# Altium schematic sheet Arduino FPGA Top-Level Interface.SchDoc("Arduino FPGA Top-Level Interface")
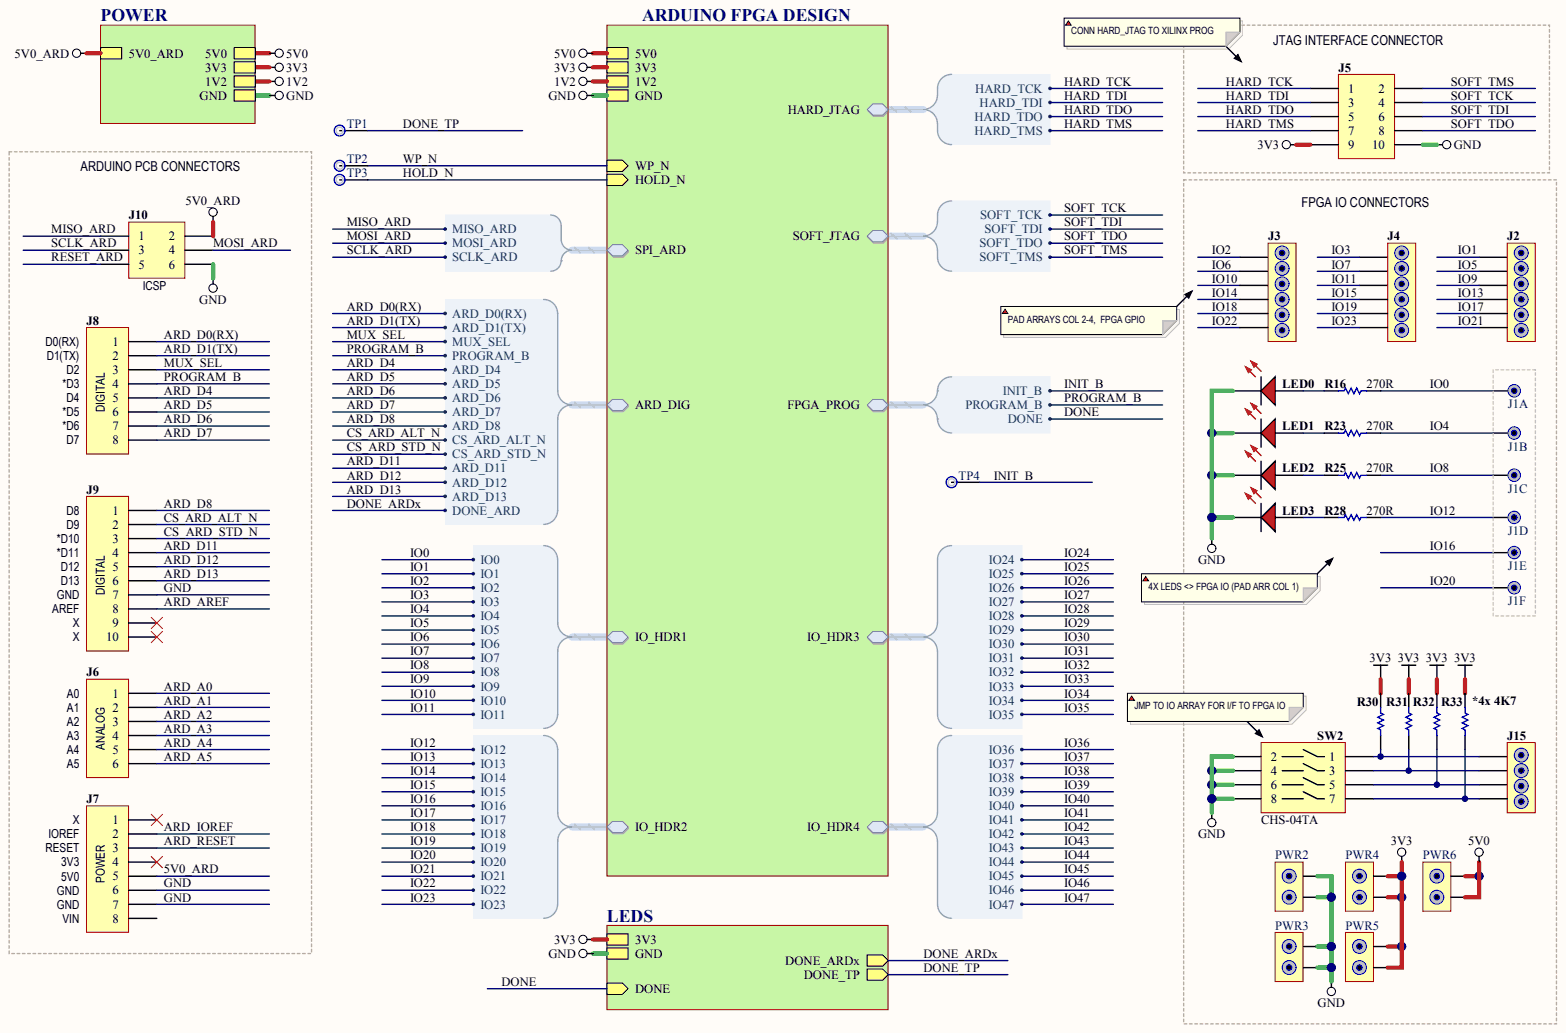
[diagram p0: Arduino FPGA Top-Level Interface.SchDoc]

## Parts
J1  HDR1X6X1  (value not drawn)  (parts A,B,C,D,E,F)
J2  6-POS VERTICAL HEADER  (value not drawn)
J3  6-POS VERTICAL HEADER  (value not drawn)
J4  6-POS VERTICAL HEADER  (value not drawn)
J5  Header 5X2  (value not drawn)
J6  Header 6  (value not drawn)
J7  Header 8  (value not drawn)
J8  Header 8  (value not drawn)
J9  Header 10  (value not drawn)
J10  Header 3X2  (value not drawn)
J15  4-POS VERTICAL HEADER  (value not drawn)
LED0  0402-LED-RED  (value not drawn)
LED1  0402-LED-RED  (value not drawn)
LED2  0402-LED-RED  (value not drawn)
LED3  0402-LED-RED  (value not drawn)
PWR2  Jumper  (value not drawn)
PWR3  Jumper  (value not drawn)
PWR4  Jumper  (value not drawn)
PWR5  Jumper  (value not drawn)
PWR6  Jumper  (value not drawn)
R16  value 270R  (hidden comment: 270R 1 0402)
R23  value 270R  (hidden comment: 270R 1 0402)
R25  value 270R  (hidden comment: 270R 1 0402)
R28  value 270R  (hidden comment: 270R 1 0402)
R30  4K7 1 0402  (value not drawn)
R31  4K7 1 0402  (value not drawn)
R32  4K7 1 0402  (value not drawn)
R33  value 4K7  (hidden comment: 4K7 1 0402)
SW2  CHS-04TA
TP1  TESTPOINT_TH  (value not drawn)
TP2  TESTPOINT_TH  (value not drawn)
TP3  TESTPOINT_TH  (value not drawn)
TP4  TESTPOINT_TH  (value not drawn)

## Nets
3V3: J5.9 PWR4.1 PWR4.2 PWR5.1 PWR5.2 R30.2 R31.2 R32.2 R33.2
5V0: PWR6.1 PWR6.2
5V0_ARD: J7.5
ARD_A0: J6.1
ARD_A1: J6.2
ARD_A2: J6.3
ARD_A3: J6.4
ARD_A4: J6.5
ARD_A5: J6.6
ARD_AREF: J9.8
ARD_D0(RX): J8.1
ARD_D1(TX): J8.2
ARD_D4: J8.5
ARD_D5: J8.6
ARD_D6: J8.7
ARD_D7: J8.8
ARD_D8: J9.1
ARD_D11: J9.4
ARD_D12: J9.5
ARD_D13: J9.6
ARD_IOREF: J7.2
ARD_RESET: J7.3
CS_ARD_ALT_N: J9.2
CS_ARD_STD_N: J9.3
DONE_TP: TP1.1
GND: J5.10 J7.6 J7.7 J9.7 J10.6 LED0.2 LED1.2 LED2.2 LED3.2 PWR2.1 PWR2.2 PWR3.1 PWR3.2 SW2.2 SW2.4 SW2.6 SW2.8
HARD_TCK: J5.1
HARD_TDI: J5.3
HARD_TDO: J5.5
HARD_TMS: J5.7
HOLD_N: TP3.1
INIT_B: TP4.1
IO0: J1.1 R16.2
IO1: J2.1
IO2: J3.1
IO3: J4.1
IO4: J1.2 R23.2
IO5: J2.2
IO6: J3.2
IO7: J4.2
IO8: J1.3 R25.2
IO9: J2.3
IO10: J3.3
IO11: J4.3
IO12: J1.4 R28.2
IO13: J2.4
IO14: J3.4
IO15: J4.4
IO16: J1.5
IO17: J2.5
IO18: J3.5
IO19: J4.5
IO20: J1.6
IO21: J2.6
IO22: J3.6
IO23: J4.6
MISO_ARD: J10.1
MOSI_ARD: J10.4
MUX_SEL: J8.3
PROGRAM_B: J8.4
RESET_ARD: J10.5
SCLK_ARD: J10.3
SOFT_TCK: J5.4
SOFT_TDI: J5.6
SOFT_TDO: J5.8
SOFT_TMS: J5.2
WP_N: TP2.1
NetJ7_1: J7.1
NetJ7_4: J7.4
NetJ7_8: J7.8
NetJ9_9: J9.9
NetJ9_10: J9.10
NetJ10_2: J10.2
NetJ15_1: J15.1 R30.1 SW2.1
NetJ15_2: J15.2 R31.1 SW2.3
NetJ15_3: J15.3 R32.1 SW2.5
NetJ15_4: J15.4 R33.1 SW2.7
NetLED0_1: LED0.1 R16.1
NetLED1_1: LED1.1 R23.1
NetLED2_1: LED2.1 R25.1
NetLED3_1: LED3.1 R28.1
1V2:
DONE:
DONE_ARDX:
IO24:
IO25:
IO26:
IO27:
IO28:
IO29:
IO30:
IO31:
IO32:
IO33:
IO34:
IO35:
IO36:
IO37:
IO38:
IO39:
IO40:
IO41:
IO42:
IO43:
IO44:
IO45:
IO46:
IO47:

## Library data of the parts
J1-J4 = (no symbol name): Manufacturer_PN: 68000-406HLF; Manufacturer Part Number: 68000-406HLF
J5 = (no symbol name): Manufacturer_PN: 67997-210HLF; LatestRevisionDate: 17-Jul-2002; LatestRevisionNote: Re-released for DXP Platform.; Manufacturer Part Number: 67997-210HLF; Publisher: Altium Limited
J6-J10 = (no symbol name): Manufacturer_PN: DNP; LatestRevisionDate: 17-Jul-2002; LatestRevisionNote: Re-released for DXP Platform.; Manufacturer Part Number: DNP; Publisher: Altium Limited
J15 = (no symbol name): Manufacturer_PN: 68000-404HLF; Manufacturer Part Number: 68000-404HLF
LED0-LED3 = (no symbol name): Manufacturer_PN: LTST-C190KRKT; ID: CMP-0201-0013; DefaultDesignator: LED?; Class: Diodes; Sub Class: LED; Reverse Voltage DC (Vr): Red; Current Average (Io): 1.8V; ComponentLink1Description: Datasheet; ComponentLink1URL: http://optoelectronics.liteon.com/upload/download/DS-22-99-0151/S_110_LTST-C190KRKT.pdf; ComponentLink2Description: Manufacturer; ComponentLink2URL: http://www.liteon.com; Manufacturer Part Number: LTST-C190KRKT
PWR2-PWR6 = (no symbol name): Manufacturer_PN: M20-9770246; Manufacturer Part Number: M20-9770246
R16 R23 R25 R28 = (no symbol name): Manufacturer_PN: RC1005F271CS; ID: CMP-0503-0059; Case-EIA: 0402; Case-Metric: 1005; Temp Coefficient ppm/°C: ±100; Tolerance %: 1%; Value (Ohms): 270; Power: 1/16 W; Technology: SMT; Manufacturer Part Number: RC1005F271CS
R30-R33 = (no symbol name): Manufacturer_PN: CR0402-FX-4701GLFDKR-ND; Case-EIA: 0402; Case-Metric: 1005; Power: 1/16 W; Temp Coefficient ppm/°C: ±100; Tolerance %: 1%; Value (Ohms): 4700; Technology: SMT; Manufacturer Part Number: CR0402-FX-4701GLFDKR-ND
  R30-R32: Value: 4K7
SW2 = (no symbol name): Manufacturer: Copal Electronics Inc; Circuit: SPST; Number of Positions: 4; Contact Rating @ Voltage: 0.1A @ 6VDC; Actuator Type: Standard; Actuator Level: Flush; Washable: Yes; Supplier 1: Digi-Key; Manufacturer Part Number: CHS-04TA; RoHS: RoHS Compliant; Category: Switches; Stock 1: 2000; Pricing 1: 500=1.33876, 1000=1.1475, 5000=1.05188 (USD); Mounting Type: Surface Mount; Orientation: Top Actuated; ComponentLink1URL: http://copal-electronics.info/en/0008e/0267f.pdf; ComponentLink1Description: http://copal-electronics.info/en/0008e/0267f.pdf; Supplier Part Number 1: 563-1007-2-ND; Manufacturer_PN: CHS-04TA
TP1-TP4 = (no symbol name): Manufacturer_PN: TESTPOINT; Manufacturer Part Number: TESTPOINT
